# Altium schematic sheet MIPI.SchDoc(MIPI)
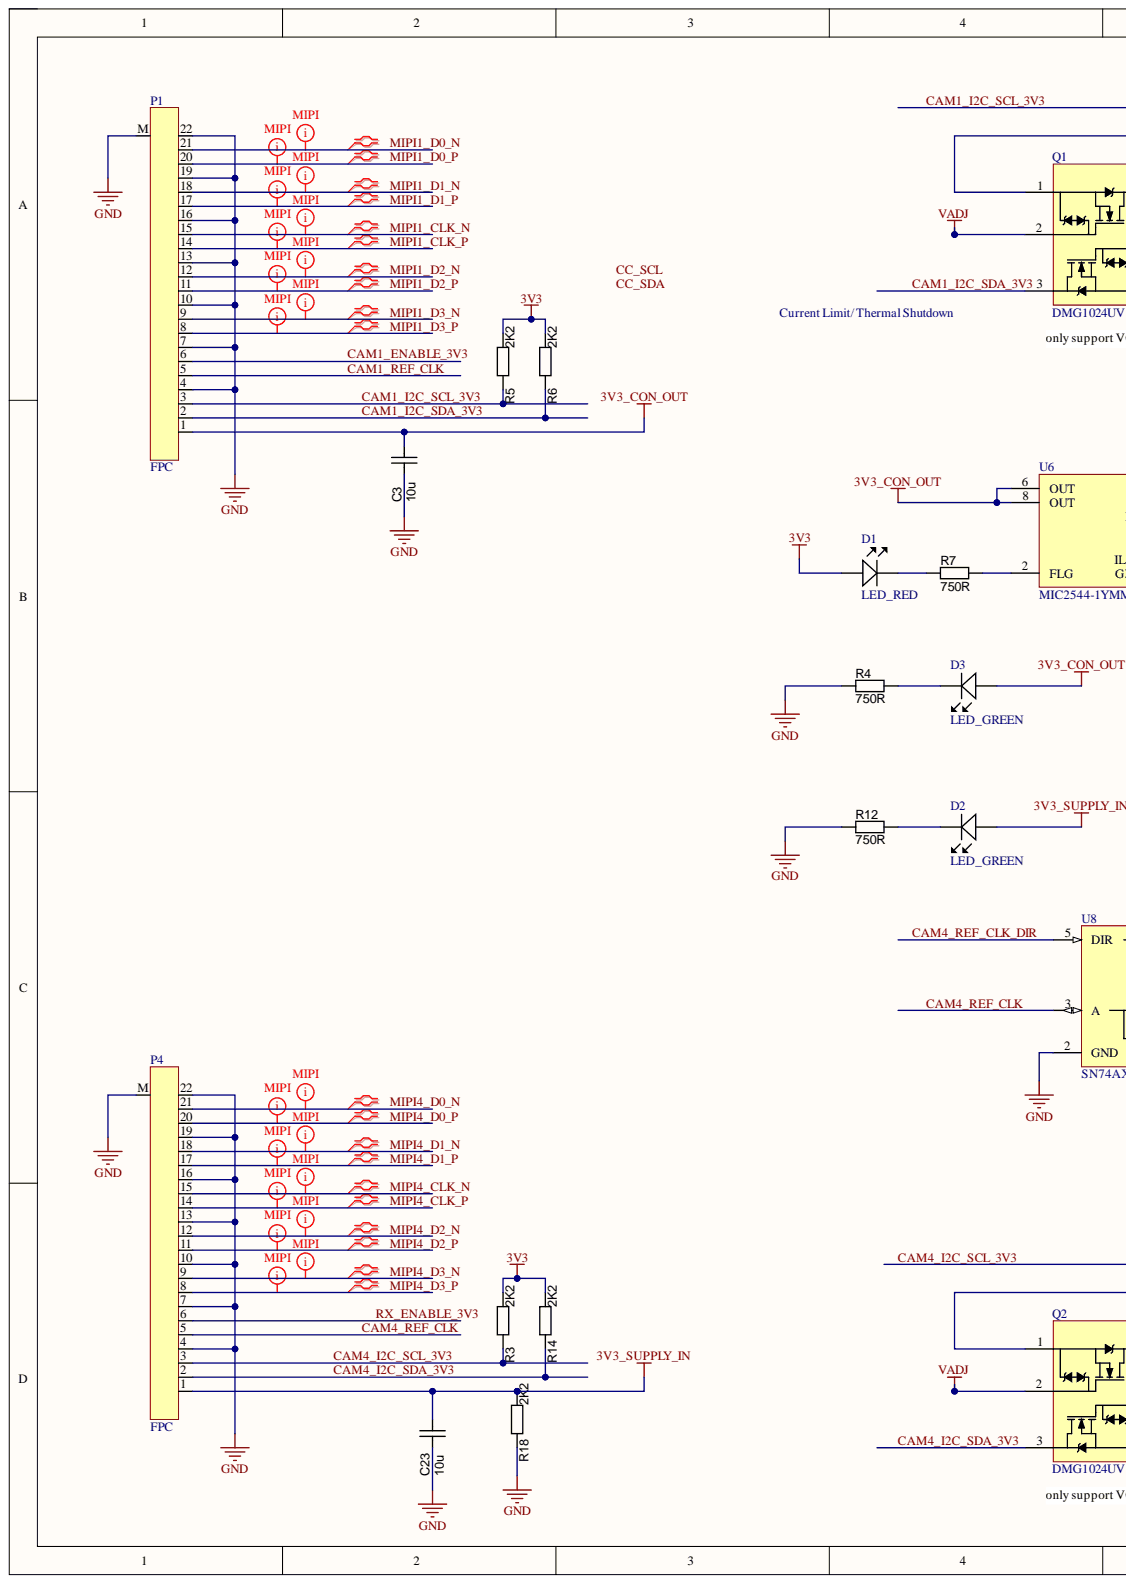
[diagram p0: MIPI.SchDoc, left]
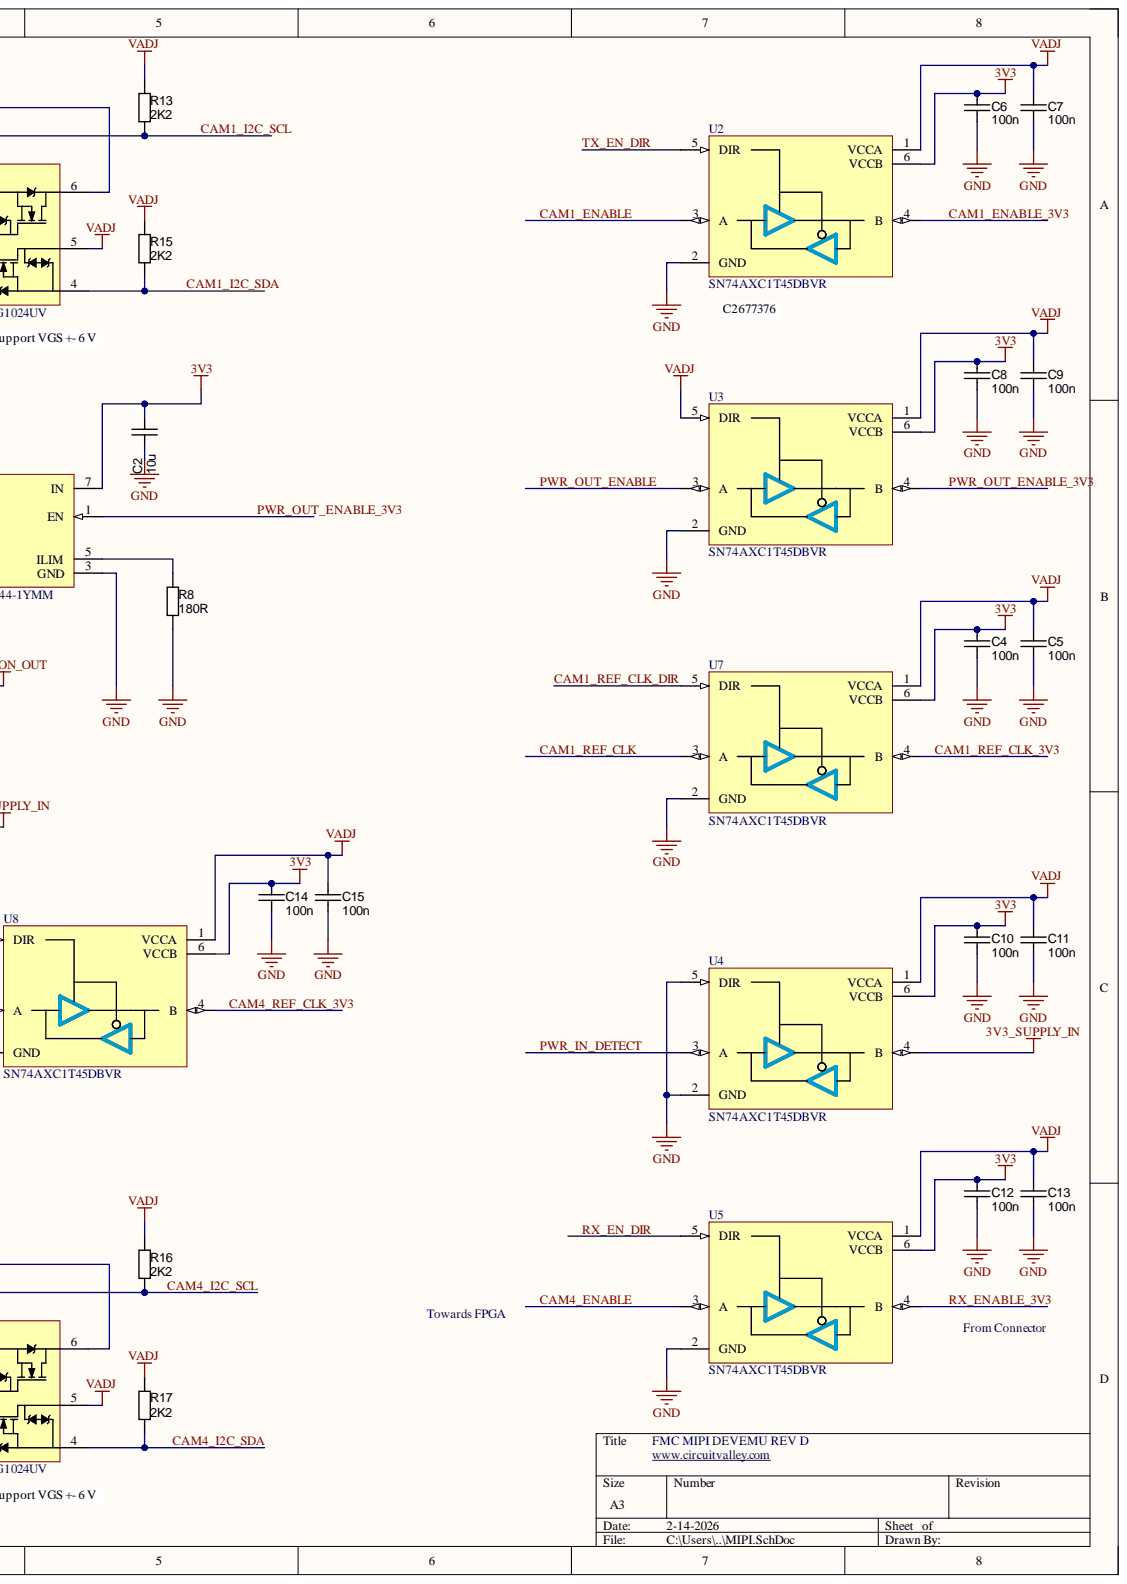
[diagram p1: MIPI.SchDoc, right]

## Parts
C2  value 10u  [CAPC1608N]  (hidden comment: approved sub OK)
C3  value 10u  [CAPC1608N]  (hidden comment: approved sub OK)
C4  100n  [CAPC1005N]
C5  100n  [CAPC1005N]
C6  100n  [CAPC1005N]
C7  100n  [CAPC1005N]
C8  100n  [CAPC1005N]
C9  100n  [CAPC1005N]
C10  100n  [CAPC1005N]
C11  100n  [CAPC1005N]
C12  100n  [CAPC1005N]
C13  100n  [CAPC1005N]
C14  100n  [CAPC1005N]
C15  100n  [CAPC1005N]
C23  value 10u  [CAPC1608N]  (hidden comment: approved sub OK)
D1  LED_RED  [1206_LED]
D2  LED_GREEN  [1206_LED]
D3  LED_GREEN  [1206_LED]
P1  FPC  [AFC11-S22ICA-00]
P4  FPC  [AFC11-S22ICA-00]
Q1  DMG1024UV  [SOT563]
Q2  DMG1024UV  [SOT563]
R3  value 2K2  [RESC1005N]  (hidden comment: Thick Film)
R4  value 750R  [RESC1608N]  (hidden comment: RES_NL_0603)
R5  value 2K2  [RESC1005N]  (hidden comment: Thick Film)
R6  value 2K2  [RESC1005N]  (hidden comment: Thick Film)
R7  value 750R  [RESC1608N]  (hidden comment: RES_NL_0603)
R8  value 180R  [RESC1608N]  (hidden comment: RES_NL_0603)
R12  value 750R  [RESC1608N]  (hidden comment: RES_NL_0603)
R13  value 2K2  [RESC1005N]  (hidden comment: Thick Film)
R14  value 2K2  [RESC1005N]  (hidden comment: Thick Film)
R15  value 2K2  [RESC1005N]  (hidden comment: Thick Film)
R16  value 2K2  [RESC1005N]  (hidden comment: Thick Film)
R17  value 2K2  [RESC1005N]  (hidden comment: Thick Film)
R18  value 2K2  [RESC1005N]  (hidden comment: Thick Film)
U2  SN74AXC1T45DBVR  [SOT23-6]
U3  SN74AXC1T45DBVR  [SOT23-6]
U4  SN74AXC1T45DBVR  [SOT23-6]
U5  SN74AXC1T45DBVR  [SOT23-6]
U6  MIC2544-1YMM  [MSOP8]
U7  SN74AXC1T45DBVR  [SOT23-6]
U8  SN74AXC1T45DBVR  [SOT23-6]

## Nets
3V3: C2.1 C4.2 C6.2 C8.2 C10.2 C12.2 C14.2 D1.A R3.1 R5.1 R6.1 R14.1 U2.6(VCCB) U3.6(VCCB) U4.6(VCCB) U5.6(VCCB) U6.7(IN) U7.6(VCCB) U8.6(VCCB)
3V3_CON_OUT: C3.1 D3.A P1.1 U6.6(OUT) U6.8(OUT)
3V3_SUPPLY_IN: C23.1 D2.A P4.1 R18.1 U4.4(B)
GND: C2.2 C3.2 C4.1 C5.1 C6.1 C7.1 C8.1 C9.1 C10.1 C11.1 C12.1 C13.1 C14.1 C15.1 C23.2 P1.4 P1.7 P1.10 P1.13 P1.16 P1.19 P1.22 P1.M P4.4 P4.7 P4.10 P4.13 P4.16 P4.19 P4.22 P4.M R4.1 R8.2 R12.1 R18.2 U2.2(GND) U3.2(GND) U4.2(GND) U4.5(DIR) U5.2(GND) U6.3(GND) U7.2(GND) U8.2(GND)
VADJ: C5.2 C7.2 C9.2 C11.2 C13.2 C15.2 Q1.2 Q1.5 Q2.2 Q2.5 R13.2 R15.2 R16.2 R17.2 U2.1(VCCA) U3.1(VCCA) U3.5(DIR) U4.1(VCCA) U5.1(VCCA) U7.1(VCCA) U8.1(VCCA)
CAM1_ENABLE: U2.3(A)
CAM1_ENABLE_3V3: P1.6 U2.4(B)
CAM1_I2C_SCL: Q1.1 R13.1
CAM1_I2C_SCL_3V3: P1.3 Q1.6 R5.2
CAM1_I2C_SDA: Q1.4 R15.1
CAM1_I2C_SDA_3V3: P1.2 Q1.3 R6.2
CAM1_REF_CLK: P1.5 U7.3(A)
CAM1_REF_CLK_3V3: U7.4(B)
CAM1_REF_CLK_DIR: U7.5(DIR)
CAM4_ENABLE: U5.3(A)
CAM4_I2C_SCL: Q2.1 R16.1
CAM4_I2C_SCL_3V3: P4.3 Q2.6 R3.2
CAM4_I2C_SDA: Q2.4 R17.1
CAM4_I2C_SDA_3V3: P4.2 Q2.3 R14.2
CAM4_REF_CLK: P4.5 U8.3(A)
CAM4_REF_CLK_3V3: U8.4(B)
CAM4_REF_CLK_DIR: U8.5(DIR)
MIPI1_CLK_N: P1.15
MIPI1_CLK_P: P1.14
MIPI1_D0_N: P1.21
MIPI1_D0_P: P1.20
MIPI1_D1_N: P1.18
MIPI1_D1_P: P1.17
MIPI1_D2_N: P1.12
MIPI1_D2_P: P1.11
MIPI1_D3_N: P1.9
MIPI1_D3_P: P1.8
MIPI4_CLK_N: P4.15
MIPI4_CLK_P: P4.14
MIPI4_D0_N: P4.21
MIPI4_D0_P: P4.20
MIPI4_D1_N: P4.18
MIPI4_D1_P: P4.17
MIPI4_D2_N: P4.12
MIPI4_D2_P: P4.11
MIPI4_D3_N: P4.9
MIPI4_D3_P: P4.8
PWR_IN_DETECT: U4.3(A)
PWR_OUT_ENABLE: U3.3(A)
PWR_OUT_ENABLE_3V3: U3.4(B) U6.1(EN)
RX_EN_DIR: U5.5(DIR)
RX_ENABLE_3V3: P4.6 U5.4(B)
TX_EN_DIR: U2.5(DIR)
NetD1_K: D1.K R7.1
NetD2_K: D2.K R12.2
NetD3_K: D3.K R4.2
NetR7_2: R7.2 U6.2(FLG)
NetR8_1: R8.1 U6.5(ILIM)
CC_SCL:
CC_SDA:

## Library data of the parts
C2 C3 C23 = CAPC_560p_0603_5%: Capacitor SMD Cer 560pF 5% 50V NP0 0603; Manufacturer 1: AVX Corporation; Manufacturer Part Number 1: 06035A561JAT2A; Capacitance: 470pF; Material: ceramic; Ratings: 50V; NP0; Applications: General Purpose; Component Kind: Standard; ComponentLink1Description: http://www.avx.com/docs/Catalogs/ccog.pdf; ComponentLink1URL: http://www.avx.com/docs/Catalogs/ccog.pdf; Height - Seated (Max): -; Lead Spacing: -; Lead Style: -; Library Name: PBL - Capacitors.SCHLIB; Mounting Type: Surface Mount, MLCC; Operating Temperature: -55°C ~ 125°C; Package / Case: 0603 (1608 metric); Size / Dimension: 0.063" L x 0.032" W (1.60mm x 0.81mm); Supplier 1: Digi-Key; Supplier Part Number 1: 478-1184-1-ND; Thickness (Max): 0.035" (0.90mm); Voltage - Rated: 50V
C4-C15 = CAPC_10n_0402_16V_10%: Capacitor SMD Cer 10nF 10% 16V X7R 0402; Manufacturer 1: AVX Corporation; Manufacturer Part Number 1: 0402YC103KAT2A; Ratings: 16V; X7R; Component Kind: Standard; ComponentLink1Description: http://www.avx.com/docs/Catalogs/cx7r.pdf; ComponentLink1URL: http://www.avx.com/docs/Catalogs/cx7r.pdf; ComponentLink2Description: http://www.yageo.com/documents/recent/UPY-GPHC_X7R_6.3V-to-50V_8.pdf; ComponentLink2URL: http://www.yageo.com/documents/recent/UPY-GPHC_X7R_6.3V-to-50V_8.pdf; Library Name: PBL - Capacitors.SCHLIB; Manufacturer 2: Yageo; Manufacturer Part Number 2: CC0402KRX7R7BB103; Operating Temperature: -55°C ~ 125°C; Package / Case: 0402 (1005 Metric); Size / Dimension: 0.039" L x 0.020" W (1.00mm x 0.50mm); Supplier 1: Digi-Key; Supplier 2: Digi-Key; Supplier Part Number 1: 478-1114-1-ND; Supplier Part Number 2: 311-1042-1-ND; Tolerance: ±10%; Value: 100n
D1-D3 = LED: Component Kind: Standard; Description: LED; Library Name: cvlib.SchLib
P1 P4 = FPC22_PIN: FPC 22 Pin; Component Kind: Standard; Library Name: cvlib.SchLib
R3 R5 R6 R13-R18 = RES_3K3_0402_5%: Resistor SMD chip 3.3k Ohm 0.063W 5% 0402; Manufacturer 1: Yageo; Manufacturer Part Number 1: RC0402JR-073K3L; Ratings: 1/16 W; Component Kind: Standard; ComponentLink1Description: http://www.yageo.com/documents/recent/PYu-RC0402_51_RoHS_L_6.pdf; ComponentLink1URL: http://www.yageo.com/documents/recent/PYu-RC0402_51_RoHS_L_6.pdf; Library Name: PBL - Resistors.SCHLIB; Material: Thick Film; Package / Case: 0402 (1005 metric); Supplier 1: Digi-Key; Supplier Part Number 1: 311-3.3KJRCT-ND; Tolerance: ±5%
R4 R7 R8 R12 = RES_NL_0603: Resistor, SMD chip; Manufacturer 1: Royal Ohm; Ratings: 1/10 W; Tolerance: ±5%; Component Kind: Standard; Library Name: PBL - Resistors.SCHLIB; Package / Case: 0603 (1608 metric)
U2-U5 U7 U8 = SN74LVC1T45DBVR: Single Bit Level Translation; Component Kind: Standard; Library Name: cvlib.SchLib
U6 = MIC2544: High Side Switch, Current limit programmable; Component Kind: Standard; Library Name: cvlib.SchLib
Q1 Q2 = DMG1024UV: Component Kind: Standard; Description: DMG1024UV; Library Name: cvlib.SchLib
